# Altium schematic sheet MCU.SchDoc(MCU)
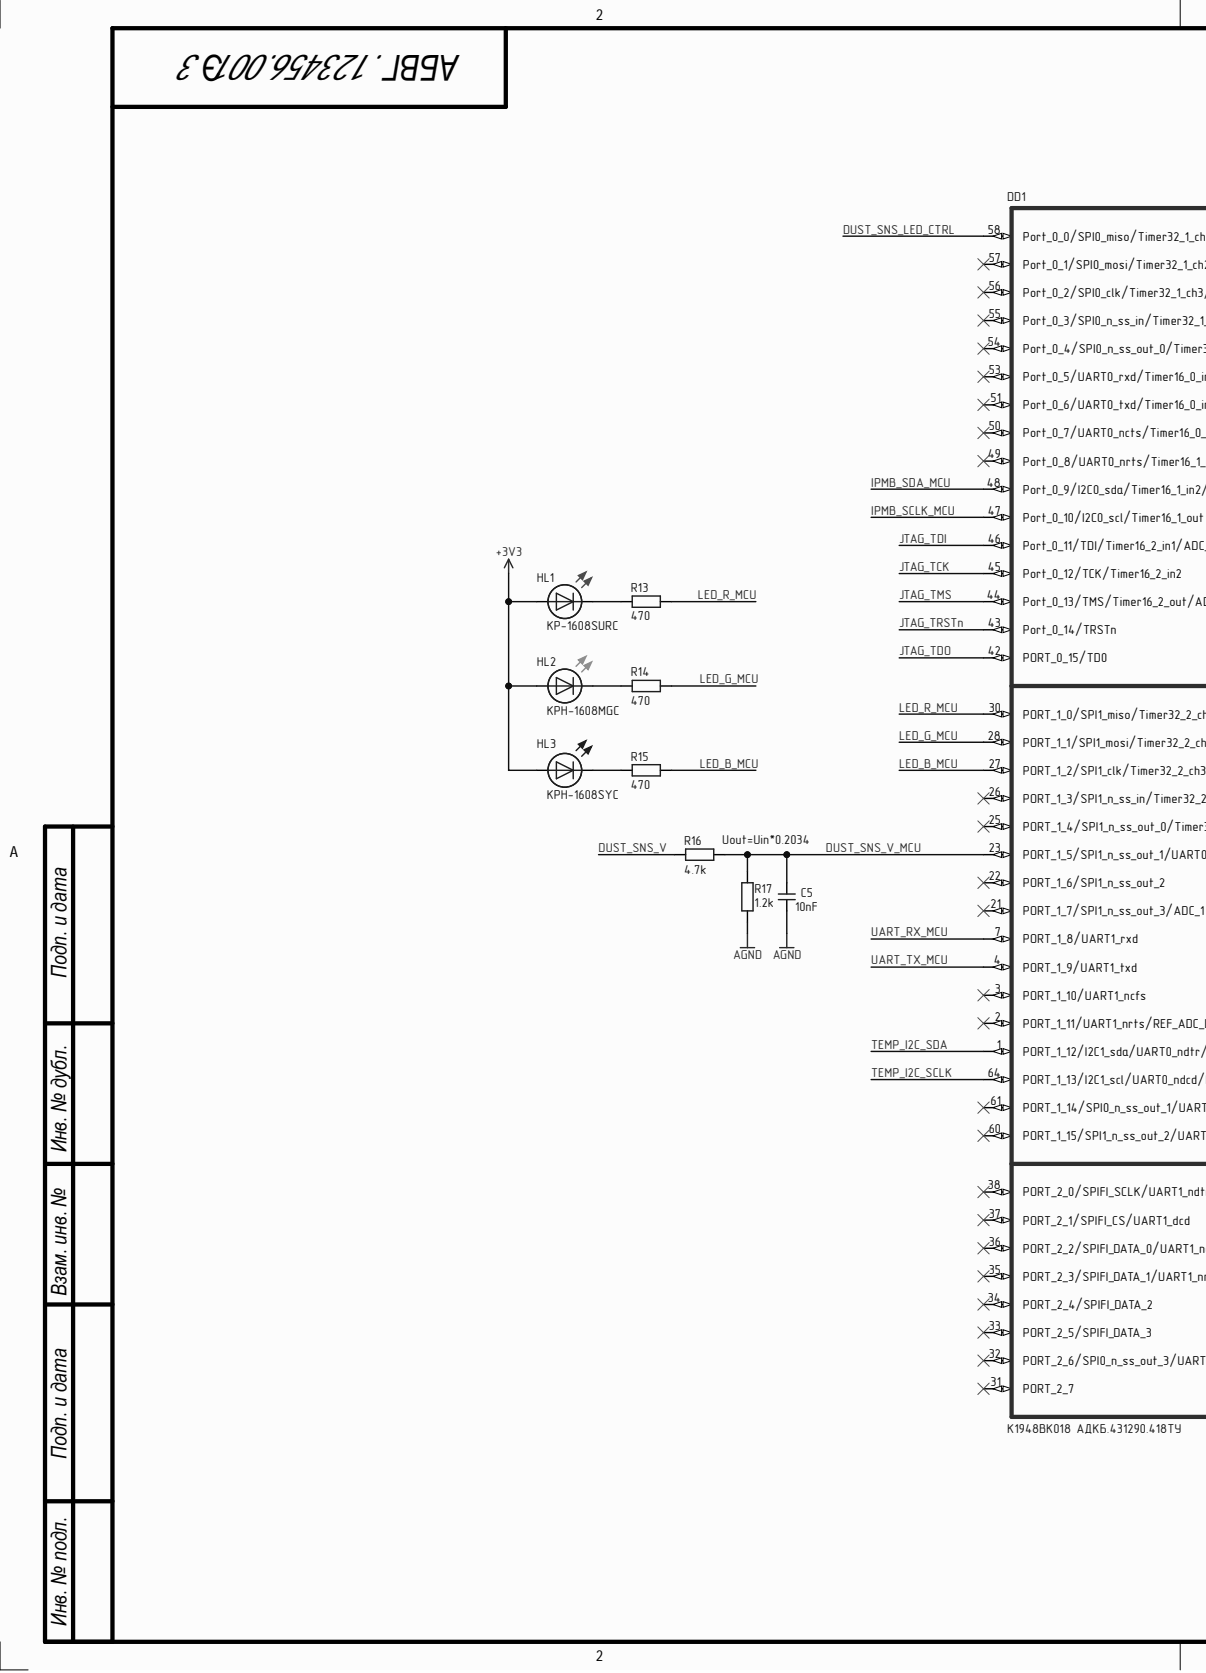
[diagram p0: MCU.SchDoc, left]
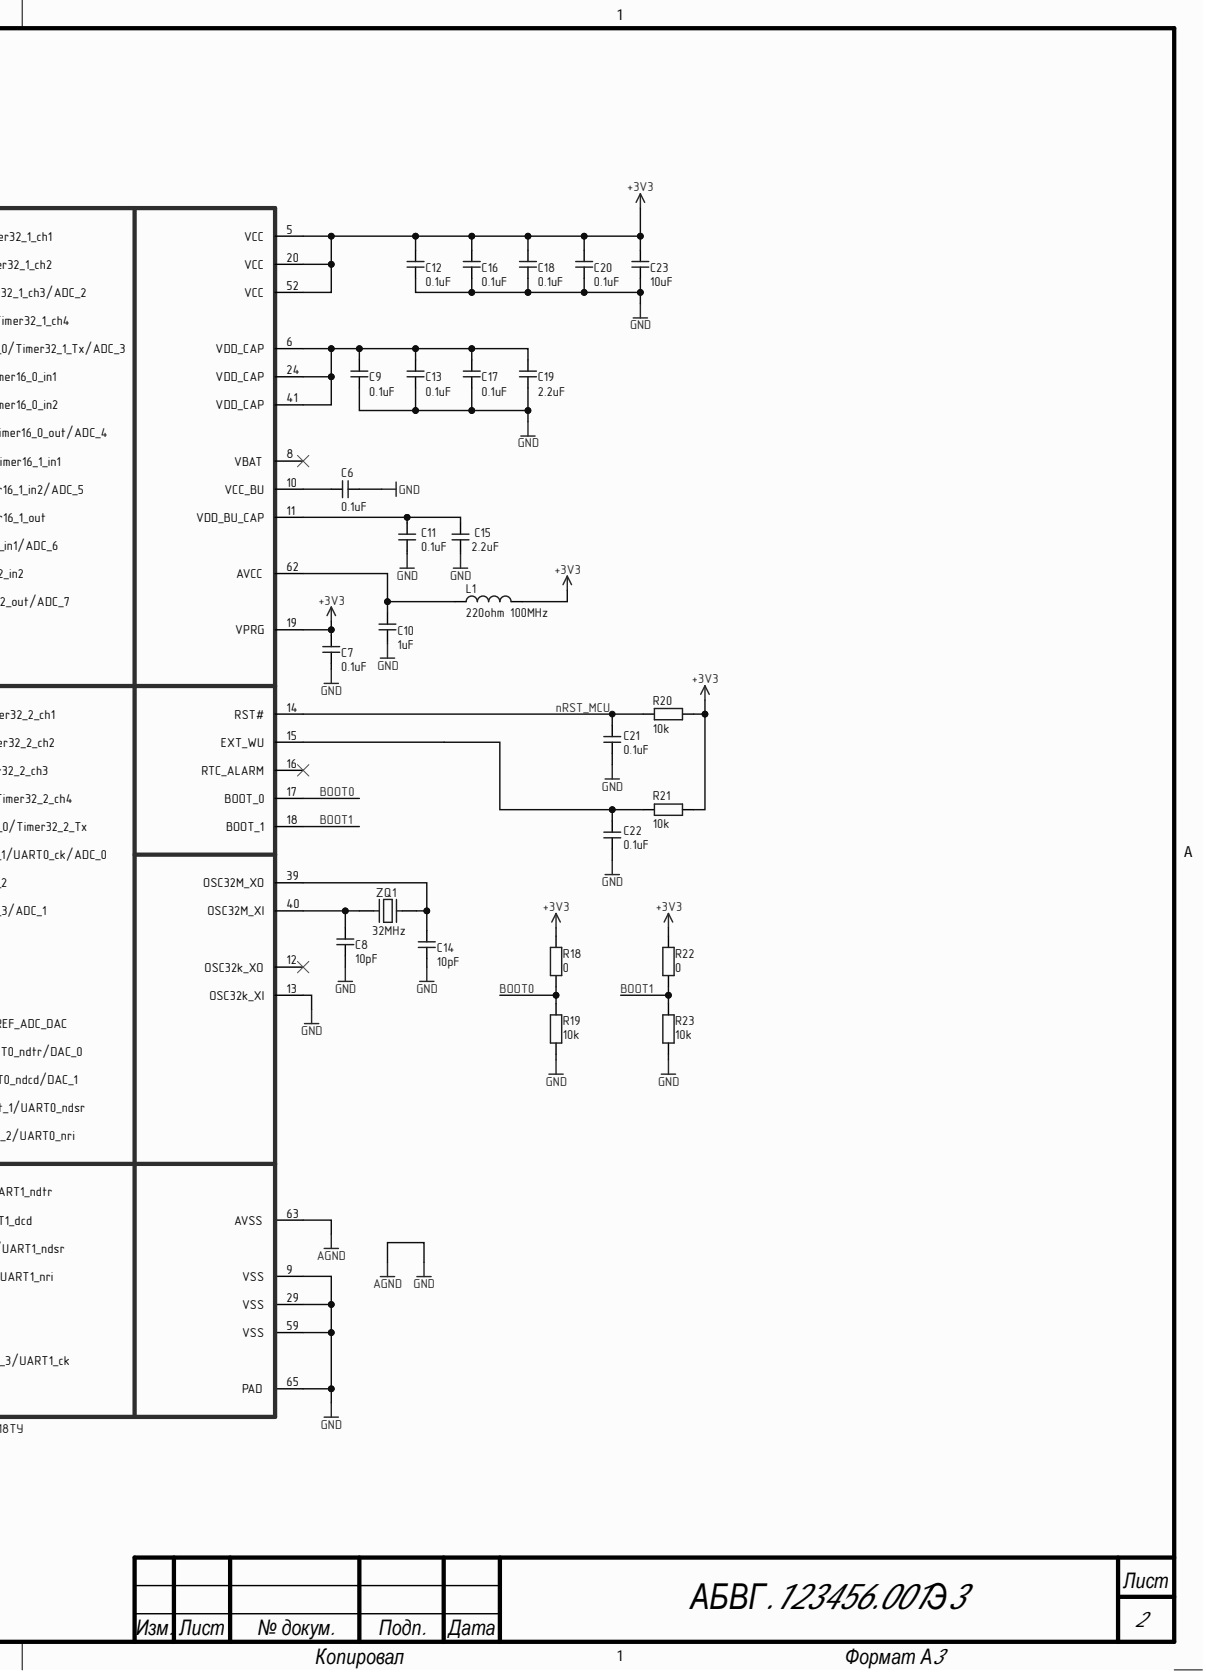
[diagram p1: MCU.SchDoc, right]

## Parts
C5  10nF
C6  0.1uF
C7  0.1uF
C8  10pF
C9  0.1uF
C10  1uF
C11  0.1uF
C12  0.1uF
C13  0.1uF
C14  10pF
C15  2.2uF
C16  0.1uF
C17  0.1uF
C18  0.1uF
C19  2.2uF
C20  0.1uF
C21  0.1uF
C22  0.1uF
C23  10uF
DD1  К1948ВК018 АДКБ.431290.418ТУ  [QFN40P800X800X76_HS-65_AMUR]
HL1  KP-1608SURC
HL2  KPH-1608MGC
HL3  KPH-1608SYC
L1  220ohm 100MHz
R13  470
R14  470
R15  470
R16  4.7k
R17  1.2k
R18  0
R19  10k
R20  10k
R21  10k
R22  0
R23  10k
ZQ1  32MHz

## Nets
+3V3: C7.2 C12.2 C16.2 C18.2 C20.2 C23.2 DD1.5(VCC) DD1.19(VPRG) DD1.20(VCC) DD1.52(VCC) HL1.1 HL2.1 HL3.1 L1.2 R18.2 R20.2 R21.2 R22.2
AGND: C5.1 C6.1 C7.1 C8.1 C9.1 C10.1 C11.2 C12.1 C13.1 C14.1 C15.1 C16.1 C17.1 C18.1 C19.1 C20.1 C21.1 C22.1 C23.1 DD1.9(VSS) DD1.13(OSC32k_XI) DD1.29(VSS) DD1.59(VSS) DD1.63(AVSS) DD1.65(PAD) R17.1 R19.1 R23.1
BOOT0: DD1.17(BOOT_0) R18.1 R19.2
BOOT1: DD1.18(BOOT_1) R22.1 R23.2
DUST_SNS_LED_CTRL: DD1.58(Port_0_0/SPI0_miso/Timer32_1_ch1)
DUST_SNS_V: R16.1
DUST_SNS_V_MCU: C5.2 DD1.23(PORT_1_5/SPI1_n_ss_out_1/UART0_ck/ADC_0) R16.2 R17.2
IPMB_SCLK_MCU: DD1.47(Port_0_10/I2C0_scl/Timer16_1_out)
IPMB_SDA_MCU: DD1.48(Port_0_9/I2C0_sda/Timer16_1_in2/ADC_5)
JTAG_TCK: DD1.45(Port_0_12/TCK/Timer16_2_in2)
JTAG_TDI: DD1.46(Port_0_11/TDI/Timer16_2_in1/ADC_6)
JTAG_TDO: DD1.42
JTAG_TMS: DD1.44(Port_0_13/TMS/Timer16_2_out/ADC_7)
JTAG_TRSTn: DD1.43(Port_0_14/TRSTn)
LED_B_MCU: DD1.27(PORT_1_2/SPI1_clk/Timer32_2_ch3) R15.2
LED_G_MCU: DD1.28(PORT_1_1/SPI1_mosi/Timer32_2_ch2) R14.2
LED_R_MCU: DD1.30(PORT_1_0/SPI1_miso/Timer32_2_ch1) R13.2
nRST_MCU: C21.2 DD1.14(RST#) R20.1
TEMP_I2C_SCLK: DD1.64(PORT_1_13/I2C1_scl/UART0_ndcd/DAC_1)
TEMP_I2C_SDA: DD1.1(PORT_1_12/I2C1_sda/UART0_ndtr/DAC_0)
UART_RX_MCU: DD1.7(PORT_1_8/UART1_rxd)
UART_TX_MCU: DD1.4(PORT_1_9/UART1_txd)
NetC6_2: C6.2 DD1.10(VCC_BU)
NetC8_2: C8.2 DD1.40(OSC32M_XI) ZQ1.1
NetC9_2: C9.2 C13.2 C17.2 C19.2 DD1.6(VDD_CAP) DD1.24(VDD_CAP) DD1.41(VDD_CAP)
NetC10_2: C10.2 DD1.62(AVCC) L1.1
NetC11_1: C11.1 C15.2 DD1.11(VDD_BU_CAP)
NetC14_2: C14.2 DD1.39(OSC32M_XO) ZQ1.2
NetC22_2: C22.2 DD1.15(EXT_WU) R21.1
NetHL1_2: HL1.2 R13.1
NetHL2_2: HL2.2 R14.1
NetHL3_2: HL3.2 R15.1

Not connected: DD1 2(PORT_1_11/UART1_nrts/REF_ADC_DAC) 3(PORT_1_10/UART1_ncfs) 8(VBAT) 12(OSC32k_XO) 16(RTC_ALARM) 21(PORT_1_7/SPI1_n_ss_out_3/ADC_1) 22(PORT_1_6/SPI1_n_ss_out_2) 25(PORT_1_4/SPI1_n_ss_out_0/Timer32_2_Tx) 26(PORT_1_3/SPI1_n_ss_in/Timer32_2_ch4) 31(PORT_2_7) 32(PORT_2_6/SPI0_n_ss_out_3/UART1_ck) 33(PORT_2_5/SPIFI_DATA_3) 34(PORT_2_4/SPIFI_DATA_2) 35(PORT_2_3/SPIFI_DATA_1/UART1_nri) 36(PORT_2_2/SPIFI_DATA_0/UART1_ndsr) 37(PORT_2_1/SPIFI_CS/UART1_dcd) 38(PORT_2_0/SPIFI_SCLK/UART1_ndtr) 49(Port_0_8/UART0_nrts/Timer16_1_in1) 50(Port_0_7/UART0_ncts/Timer16_0_out/ADC_4) 51(Port_0_6/UART0_txd/Timer16_0_in2) 53(Port_0_5/UART0_rxd/Timer16_0_in1) 54(Port_0_4/SPI0_n_ss_out_0/Timer32_1_Tx/ADC_3) 55(Port_0_3/SPI0_n_ss_in/Timer32_1_ch4) 56(Port_0_2/SPI0_clk/Timer32_1_ch3/ADC_2) 57(Port_0_1/SPI0_mosi/Timer32_1_ch2) 60(PORT_1_15/SPI1_n_ss_out_2/UART0_nri) 61(PORT_1_14/SPI0_n_ss_out_1/UART0_ndsr)

## Library data of the parts
C5 = GRM21BR72E103KW03L: Конденсатор 0805-X7R-50В-10мкФ ± 10%; Manufacturer: Murata Electronics; Database Table Name: capacitors.csv; Dielectric type: X7R; Group: Конденсаторы; Type: Конденсатор керамический; Voltage: 250V; Component Kind: Standard; Country: Япония; Library Name: ComponentsDb.DbLib; Manufacturer Part Number: GRM21BR72E103KW03L; Name: GRM21BR72E103KW03L; Package: SMD0805; Tolerance: ± 10%; Value: 10nF
C6 C7 C9 C11-C13 C16-C18 C20-C22 = GRM21BR71H104KA01L: Конденсатор 0805-X7R-50В-0,1мкФ ± 10%; Manufacturer: Murata Electronics; Database Table Name: capacitors.csv; Dielectric type: X7R; Group: Конденсаторы; Type: Конденсатор керамический; Voltage: 50V; Component Kind: Standard; Country: Япония; Library Name: ComponentsDb.DbLib; Manufacturer Part Number: GRM21BR71H104KA01L; Name: GRM21BR71H104KA01L; Package: SMD0805; Tolerance: ± 10%; Value: 0.1uF
C8 C14 = GRM2165C1H100JZ01D: Конденсатор 0805-C0G-50В-10пФ ± 5%; Manufacturer: Murata Electronics; Database Table Name: capacitors.csv; Dielectric type: C0G; Group: Конденсаторы; Type: Конденсатор керамический; Voltage: 50V; Component Kind: Standard; Country: Япония; Library Name: ComponentsDb.DbLib; Manufacturer Part Number: GRM2165C1H100JZ01D; Name: GRM2165C1H100JZ01D; Package: SMD0805; Tolerance: ± 5%; Value: 10pF
C10 = GRM21BR71H105KA12L: Конденсатор 0805-X7R-50В-1мкФ ± 10%; Manufacturer: Murata Electronics; Database Table Name: capacitors.csv; Dielectric type: X7R; Group: Конденсаторы; Type: Конденсатор керамический; Voltage: 50V; Component Kind: Standard; Country: Япония; Library Name: ComponentsDb.DbLib; Manufacturer Part Number: GRM21BR71H105KA12L; Name: GRM21BR71H105KA12L; Package: SMD0805; Tolerance: ± 10%; Value: 1uF
C15 C19 = GRM21BR71E225KE11L: Конденсатор 0805-X7R-25В-2.2мкФ ± 10%; Manufacturer: Murata Electronics; Database Table Name: capacitors.csv; Dielectric type: X7R; Group: Конденсаторы; Type: Конденсатор керамический; Voltage: 25V; Component Kind: Standard; Country: Япония; Library Name: ComponentsDb.DbLib; Manufacturer Part Number: GRM21BR71E225KE11L; Name: GRM21BR71E225KE11L; Package: SMD0805; Tolerance: ± 10%; Value: 2.2uF
C23 = GRM31CR61H106KA12L: Конденсатор 1206-X5R-50В-10мкФ ± 10%; Manufacturer: Murata Electronics; Database Table Name: capacitors.csv; Dielectric type: X5R; Group: Конденсаторы; Type: Конденсатор керамический; Voltage: 50V; Component Kind: Standard; Country: Япония; Library Name: ComponentsDb.DbLib; Manufacturer Part Number: GRM31CR61H106KA12L; Name: GRM31CR61H106KA12L; Package: SMD1206; Tolerance: ± 10%; Value: 10uF
DD1 = К1948ВК018 АДКБ.431290.418ТУ: Микроконтроллер К1948ВК018 АДКБ.431290.418ТУ; Manufacturer: АО «МИКРОН»; Database Table Name: digital_ics.csv; Group: Микросхемы; Max Temperature: 85°С; Min Temperature: -45°С; Supply Voltage: 3,3V; Component Kind: Standard; Country: Россия; HelpURL: https://mikron.ru/upload/docs/mcu/Information_AMUR_MIK32.pdf; Library Name: ComponentsDb.DbLib; Manufacturer Part Number: К1948ВК018 АДКБ.431290.418ТУ; Name: К1948ВК018 АДКБ.431290.418ТУ; Package: QFN40; Type: Микроконтроллер
HL1 = KP-1608SURC: Светодиод KP-1608SURC; Manufacturer: Kingbright; Database Table Name: diodes.csv; Group: Светодиоды; Max If: 20mA; Max Vr: 5V; Component Kind: Standard; Country: Тайвань; Library Name: ComponentsDb.DbLib; Manufacturer Part Number: KP-1608SURC; Name: KP-1608SURC; Package: SMD1608; Type: Светодиод
HL2 = KPH-1608MGC: Светодиод KPH-1608MGC; Manufacturer: Kingbright; Database Table Name: diodes.csv; Group: Светодиоды; Max If: 20mA; Max Vr: 5V; Component Kind: Standard; Country: Тайвань; Library Name: ComponentsDb.DbLib; Manufacturer Part Number: KPH-1608MGC; Name: KPH-1608MGC; Package: SMD1608; Type: Светодиод
HL3 = KPH-1608SYC: Светодиод KPH-1608SYC; Manufacturer: Kingbright; Database Table Name: diodes.csv; Group: Светодиоды; Max If: 20mA; Max Vr: 5V; Component Kind: Standard; Country: Тайвань; Library Name: ComponentsDb.DbLib; Manufacturer Part Number: KPH-1608SYC; Name: KPH-1608SYC; Package: SMD1608; Type: Светодиод
L1 = BLM21PG221SN1D: Индуктивность ЭМП 0805-2A-220Ом ± 25% 100MHz; Manufacturer: Murata Electronics; Current: 2000mA; Database Table Name: inductors.csv; Group: Индуктивности; Rdc: 0.05Ohm; Type: Дроссель ЭМП; Component Kind: Standard; Country: Япония; HelpURL: https://static.chipdip.ru/lib/250/DOC000250690.pdf; Library Name: ComponentsDb.DbLib; Manufacturer Part Number: BLM21PG221SN1D; Name: BLM21PG221SN1D; Package: SMD0805; Tolerance: ±25%; Value: 220ohm 100MHz
R13-R15 = RC0603FR-07470RL: Резистор 0603-0,1Вт-470Ом ± 1%; Manufacturer: Yageo; Database Table Name: resistors.csv; Group: Резисторы; Power: 0.1W; Voltage: 75V; Component Kind: Standard; Country: Тайвань; Library Name: ComponentsDb.DbLib; Manufacturer Part Number: RC0603FR-07470RL; Name: RC0603FR-07470RL; Package: SMD0603; Tolerance: ± 1%; Type: Резистор; Value: 470
R16 = RC0603FR-074K7L: Резистор 0603-0,1Вт-4.7кОм ± 1%; Manufacturer: Yageo; Database Table Name: resistors.csv; Group: Резисторы; Power: 0.1W; Voltage: 75V; Component Kind: Standard; Country: Тайвань; HelpURL: https://static.chipdip.ru/lib/175/DOC011175144.pdf; Library Name: ComponentsDb.DbLib; Manufacturer Part Number: RC0603FR-074K7L; Name: RC0603FR-074K7L; Package: SMD0603; Tolerance: ± 1%; Type: Резистор; Value: 4.7k
R17 = RC0603FR-071K2L: Резистор 0603-0,1Вт-1.2кОм ± 1%; Manufacturer: Yageo; Database Table Name: resistors.csv; Group: Резисторы; Power: 0.1W; Voltage: 75V; Component Kind: Standard; Country: Тайвань; Library Name: ComponentsDb.DbLib; Manufacturer Part Number: RC0603FR-071K2L; Name: RC0603FR-071K2L; Package: SMD0603; Tolerance: ± 1%; Type: Резистор; Value: 1.2k
R18 R22 = RC0603FR-070RL: Резистор 0603-0,1Вт-0Ом ± 1%; Manufacturer: Yageo; Database Table Name: resistors.csv; Group: Резисторы; Power: 0.1W; Voltage: 75V; Component Kind: Standard; Country: Тайвань; Library Name: ComponentsDb.DbLib; Manufacturer Part Number: RC0603FR-070RL; Name: RC0603FR-070RL; Package: SMD0603; Tolerance: ± 1%; Type: Резистор; Value: 0
R19-R21 R23 = RC0603FR-0710KL: Резистор 0603-0,1Вт-10кОм ± 1%; Manufacturer: Yageo; Database Table Name: resistors.csv; Group: Резисторы; Power: 0.1W; Voltage: 75V; Component Kind: Standard; Country: Тайвань; HelpURL: https://static.chipdip.ru/lib/175/DOC011175144.pdf; Library Name: ComponentsDb.DbLib; Manufacturer Part Number: RC0603FR-0710KL; Name: RC0603FR-0710KL; Package: SMD0603; Tolerance: ± 1%; Type: Резистор; Value: 10k
ZQ1 = SJK-7U-32.000-10-10-40-C-10: Резонатор кварцевый SJK-7U-32.000-10-10-40-C-10; Manufacturer: SJK; Database Table Name: crystals.csv; ESR: 60Ohm; Group: Кварцы; Load Capacity: 10pF; Tolerance: ±30ppm; Component Kind: Standard; Country: Китай; Freq: 32MHz; HelpURL: https://static.chipdip.ru/lib/087/DOC053087027.pdf; Library Name: ComponentsDb.DbLib; Manufacturer Part Number: SJK-7U-32.000-10-10-40-C-10; Name: SJK-7U-32.000-10-10-40-C-10; Package: SMD32x25x07; Type: Кварцевый резонатор
